# Altium schematic sheet HoopLightv2SCH.SchDoc(HoopLightv2SCH)
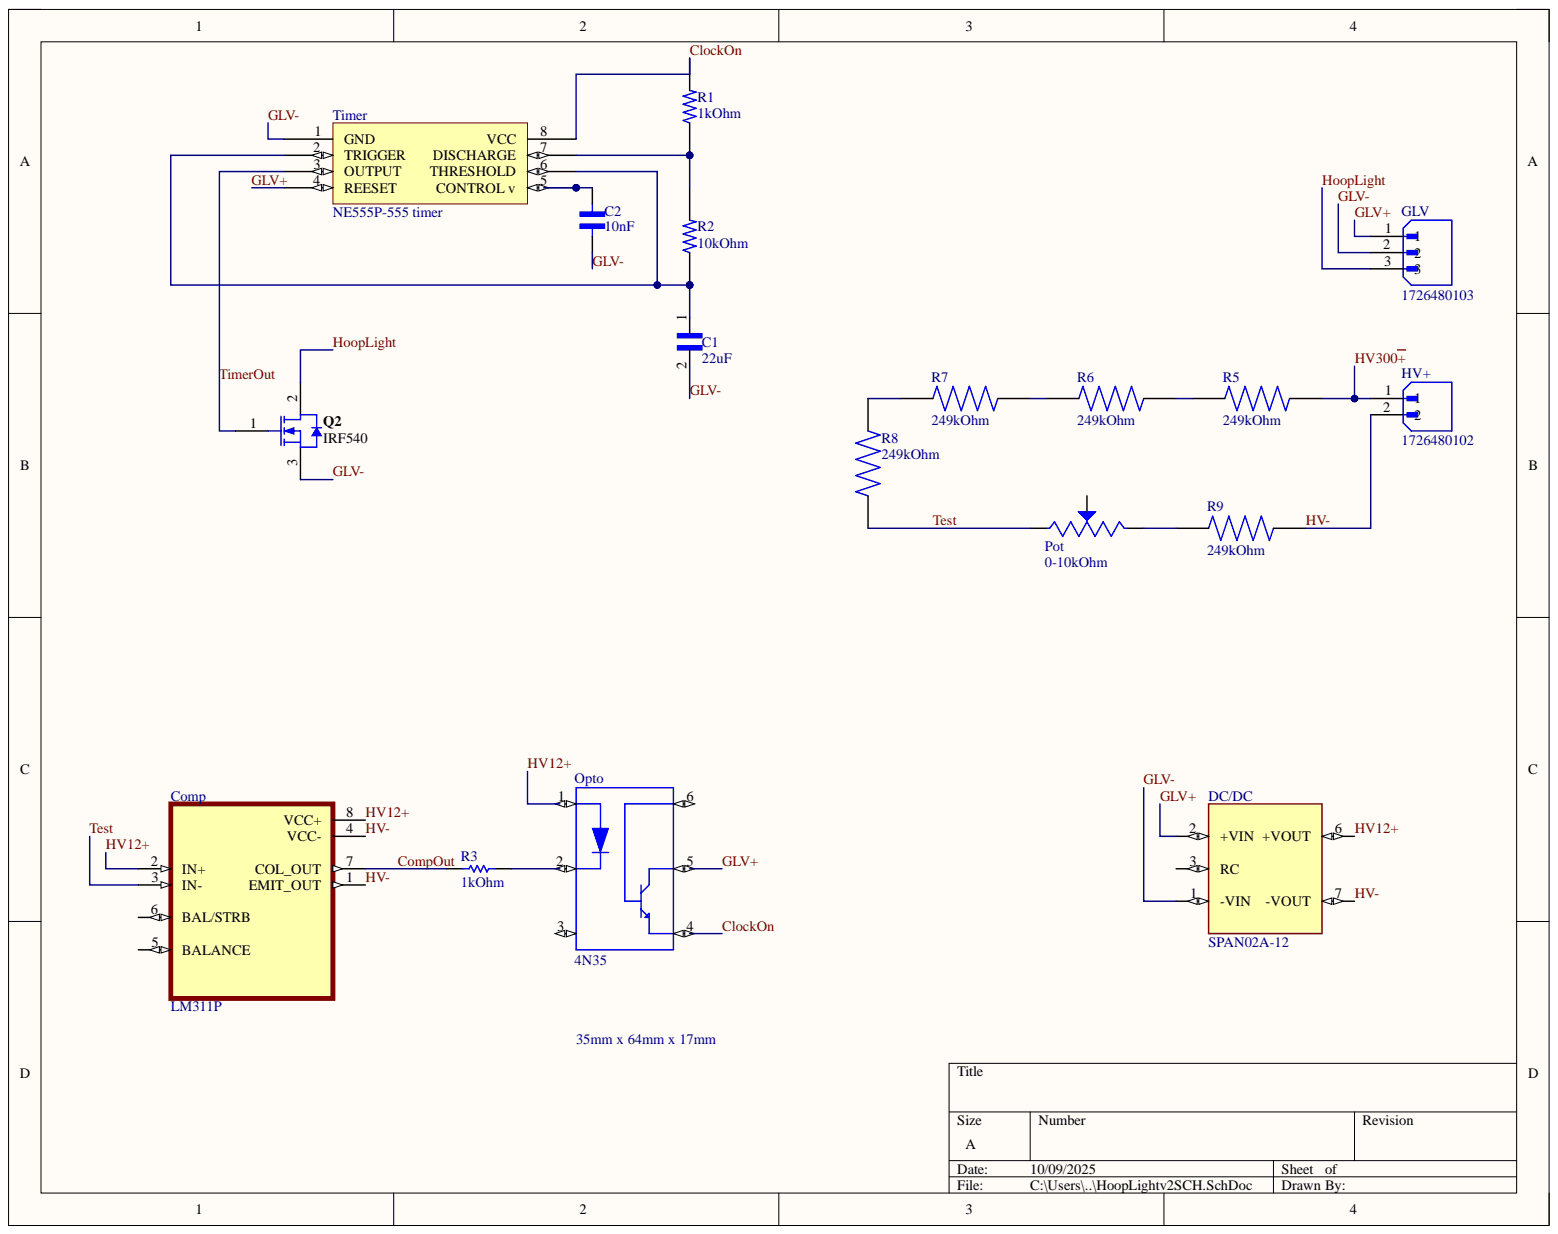
[diagram p0: HoopLightv2SCH.SchDoc]

## Parts
C1  22uF  [CAPC2012X145N]
C2  10nF  [CAPC2012X88N]
Comp  LM311P  [DIP794W45P254L959H508Q8]
DC/DC  SPAN02A-12  [SPAN02]
GLV  1726480103  [MOLEX_1726480103]
HV+  1726480102  [MOLEX_1726480102]
Opto  4N35  [DIP6-2.54-7.3X6.5MM]
Pot  0-10kOhm  [TRIM_3296W-1-103]
Q2  IRF540  [VISH-TO-220AB-3_A]
R1  1kOhm  [RESC1607X60N]
R2  10kOhm  [RESC1607X60N]
R3  1kOhm  [RESC2013X70X40NL20T20]
R5  249kOhm  [RESC1608X55N]
R6  249kOhm  [RESC1608X55N]
R7  249kOhm  [RESC1608X55N]
R8  249kOhm  [RESC1608X55N]
R9  249kOhm  [RESC1608X55N]
Timer  NE555P-555 timer  [NE555P-555 timer-Footprint-1]

## Nets
ClockOn: Opto.4 R1.2 Timer.8(VCC)
CompOut: Comp.7(COL_OUT) R3.1
GLV+: DC/DC.2(+VIN) GLV.1 Opto.5 Timer.4(REESET)
GLV-: C1.2 C2.2 DC/DC.1(-VIN) GLV.2 Q2.3 Timer.1(GND)
HoopLight: GLV.3 Q2.2
HV12+: Comp.2(IN+) Comp.8(VCC+) DC/DC.6(+VOUT) Opto.1
HV300~{+}: HV+.1 R5.2
HV-: Comp.1(EMIT_OUT) Comp.4(VCC-) DC/DC.7(-VOUT) HV+.2 R9.2
Test: Comp.3(IN-) Pot.1 R8.1
TimerOut: Q2.1 Timer.3(OUTPUT)
NetC1_1: C1.1 R2.1 Timer.2(TRIGGER) Timer.6(THRESHOLD)
NetC2_1: C2.1 Timer.5(CONTROL_v)
NetOpto_2: Opto.2 R3.2
NetPot_3: Pot.3 R9.1
NetR1_1: R1.1 R2.2 Timer.7(DISCHARGE)
NetR5_1: R5.1 R6.2
NetR6_1: R6.1 R7.2
NetR7_1: R7.1 R8.2

Not connected: Comp 5(BALANCE) 6(BAL/STRB); DC/DC 3(RC); Opto 3 6; Pot 2

## Library data of the parts
C1 = C2012X5R1V226M125AC: 22ÂµF Â±20% 35V Ceramic Capacitor X5R 0805 (2012 Metric); MF: TDK; Availability: In Stock; Check_prices: https://www.snapeda.com/parts/C2012X5R1V226M125AC/TDK-Lambda+Americas+Inc/view-part/?ref=eda; Component Kind: Standard; Library Name: C2012X5R1V226M125AC.IntLib; MP: C2012X5R1V226M125AC; Package: 2012 TDK; Price: None; Purchase-URL: https://pricing.snapeda.com/search/part/C2012X5R1V226M125AC/?ref=eda; SnapEDA_Link: https://www.snapeda.com/parts/C2012X5R1V226M125AC/TDK-Lambda+Americas+Inc/view-part/?ref=snap
C2 = C0805C103K5RACTU: Capacitor;Ceramic;Cap;.010uF;Tol+10%;SMT;Vol-Rtg 50V;X7R;TR | KEMET C0805C103K5RACTU; Check_prices: https://www.snapeda.com/parts/C0805C103K5RACTU/KEMET/view-part/?ref=eda; Component Kind: Standard; Library Name: C0805C103K5RACTU.IntLib; MF: KEMET; MP: C0805C103K5RACTU; Package: 805-2 KEMET; SnapEDA_Link: https://www.snapeda.com/parts/C0805C103K5RACTU/KEMET/view-part/?ref=snap
Comp = CMP-313-000000-1: High Speed, 30V, Differential Comparator With Strobes; MF: Texas Instruments; Check_prices: https://www.snapeda.com/parts/LM311P/Texas+Instruments/view-part/?ref=eda; Component Kind: Standard; Component Type: Standard; Design Item ID: LM311P; MP: LM311P; Package: PDIP-8 Texas; Pin Count: 8; SnapEDA_Link: https://www.snapeda.com/parts/LM311P/Texas+Instruments/view-part/?ref=snap; Source: LM311P.SchLib; Library Name: Brigham Young University
DC/DC = CMP-00016-1: Isolated Module DC DC Converter 1 Output 12V - - - 167mA 9V - 18V Input; MF: MEAN WELL USA Inc.; Check_prices: https://www.snapeda.com/parts/SPAN02A-12/Mean+Well/view-part/?ref=eda; Component Kind: Standard; Component Type: Standard; Design Item ID: SPAN02A-12; MP: SPAN02A-12; Package: SIP-8 MEAN WELL; Pin Count: 5; SnapEDA_Link: https://www.snapeda.com/parts/SPAN02A-12/Mean+Well/view-part/?ref=snap; Source: SPAN02A-12.SchLib; Library Name: Brigham Young University
GLV = CMP-308-000184-2: Connector Header Through Hole, Right Angle 3 position 0.165in (4.20mm); Availability: Unavailable; Component Kind: Standard; Component Type: Standard; ComponentLink1Description: 609-3321-ND; ComponentLink2Description: CP-037A-ND; ComponentLink3Description: Digikey; ComponentLink4Description: Digi-Key; Design Item ID: 1726480103; MP: 1726480103; Package: None; Pin Count: 3; Price: None; Source: 1726480103.SchLib; Library Name: Brigham Young University
HV+ = CMP-308-000183-1: Connector Header Through Hole, Right Angle 2 position 0.165in (4.20mm); Availability: Unavailable; Component Kind: Standard; Component Type: Standard; Design Item ID: 1726480102; MP: 1726480102; Package: None; Pin Count: 2; Price: None; Source: 1726480102.SchLib; Library Name: Brigham Young University
Opto = CMP-353-000000-1: Optoisolator Transistor with Base Output 5000Vrms 1 Channel 6-DIP; MF: Vishay; Availability: Good; Check_prices: https://www.snapeda.com/parts/4N35/Vishay%20Semiconductor%20Opto%20Division/view-part/579099/?ref=eda; Component Kind: Standard; Component Type: Standard; Design Item ID: DIP-OPTOISO-3.55KV-TRANS-W/BASE-6DIP(4N35); MP: 4N35; Package: DIP-6 Texas Instruments; Pin Count: 6; Price: None; Purchase-URL: https://pricing.snapeda.com/search/part/4N35/?ref=eda; SnapEDA_Link: https://www.snapeda.com/parts/4N35/Vishay%20Semiconductor%20Opto%20Division/view-part/579099/?ref=snap; Source: DIP-OPTOISO-3.55KV-TRANS-W_BASE-6DIP(4N35).SchLib; Library Name: Brigham Young University
Pot = CMP-361-000003-1: Trimmer,Cermet,Rest 10 Kilohms,PCB,25 Turns, Pwr-Rtg 0.5W; MF: Bourns; MP: 3296W-1-103; Check_prices: https://www.snapeda.com/parts/3296W-1-103/Bourns/view-part/?ref=eda; Component Kind: Standard; Component Type: Standard; Design Item ID: 3296W-1-103; Package: NON STANDARD-3 Bourns; Pin Count: 3; SnapEDA_Link: https://www.snapeda.com/parts/3296W-1-103/Bourns/view-part/?ref=snap; Source: 3296W-1-103.SchLib; Library Name: Brigham Young University
Q2 = CMP-2000-06461-1: Power MOSFET, 100 VDS, -55 to 175 degC, 3-Pin TO-220AB, RoHS, Tube; Manufacturer: Vishay; Brand: VishaySemiconductors; Channel Mode: Enhancement; Configuration: Single; Fall Time: 43ns; Id - Continuous Drain Current: 28A; Number of Channels: 1Channel; Pd - Power Dissipation: 150W; Rds On - Drain-Source Resistance: 77mOhms; Rise Time: 44ns; Transistor Polarity: N-Channel; Transistor Type: 1N-Channel; Typical Turn-Off Delay Time: 53ns; Typical Turn-On Delay Time: 11ns; Unit Weight: 0.211644oz; Vds - Drain-Source Breakdown Voltage: 100V; Vgs - Gate-Source Voltage: 20V; &lt;PCN&gt;: http://www.mouser.com/PCN/Vishay_SIL_0182015.pdf; Component Kind: Standard; Datasheet URL: http://www.vishay.com/docs/91021/91021.pdf; Datasheet Version: Rev. B, 03/2011; Factory Pack Quantity: 50; Height: 9.01mm; Length: 10.51mm; Library Name: Altium Content Vault; Manufacturer URL: http://www.vishay.com/; Maximum Operating Temperature: +175C; Minimum Operating Temperature: -55C; Mounting Style: ThroughHole; Mounting Technology: ThroughHole; Package / Case: TO-220-3; Package Description: 3-Pin Flange Mount, Body 10.275 x 4.45 mm, Pitch 2.54 mm; Package Reference: TO-220AB-3; Package URL: http://www.vishay.com/docs/71195/to220ab.pdf; Packaging: Tube; Product Category: MOSFET; RoHS: NoRoHSVersionAvailable; Technology: Si; Width: 4.7mm
R1 = RC0603FR-071ML: Thick film general purpose; MF: {'manufacturer': u'YAGEO'}; Availability: Unavailable; Component Kind: Standard; Library Name: RC0603FR-071ML.IntLib; MP: RC0603FR-071ML; Package: None; Price: None
R2 = RC0603FR-0710KL: 10 kOhms Â±1% 0.1W, 1/10W Chip Resistor 0603 (1608 Metric) Moisture Resistant Thick Film; MF: Yageo; Check_prices: https://www.snapeda.com/parts/RC0603FR-0710KL/Yageo/view-part/?ref=eda; Component Kind: Standard; Library Name: RC0603FR-0710KL.IntLib; MP: RC0603FR-0710KL; Package: 0603 Yageo; SnapEDA_Link: https://www.snapeda.com/parts/RC0603FR-0710KL/Yageo/view-part/?ref=snap
R3 = CMP-00005-1: Fixed Resistor, Metal Glaze/thick Film, 0.5W, 1000ohm, 400V, 5% +/-Tol, 200ppm/Cel, Surface Mount, 0805; Component Kind: Standard; Library Name: Brigham Young University
R5-R9 = CMP-361-000001-1: RES SMD 249K OHM 0.5% 1/10W 0603; MP: RC0603DR-07249KL; Availability: Unavailable; Component Kind: Standard; Component Type: Standard; Design Item ID: RC0603DR-07249KL; Package: None; Pin Count: 2; Price: None; Source: RC0603DR-07249KL.SchLib; Library Name: Brigham Young University
Timer = CMP-003-00030-1: 555 timer; Component Kind: Standard; Library Name: Luke Johnson
